# Altium schematic sheet schematic_debugger.SchDoc(schematic_debugger)
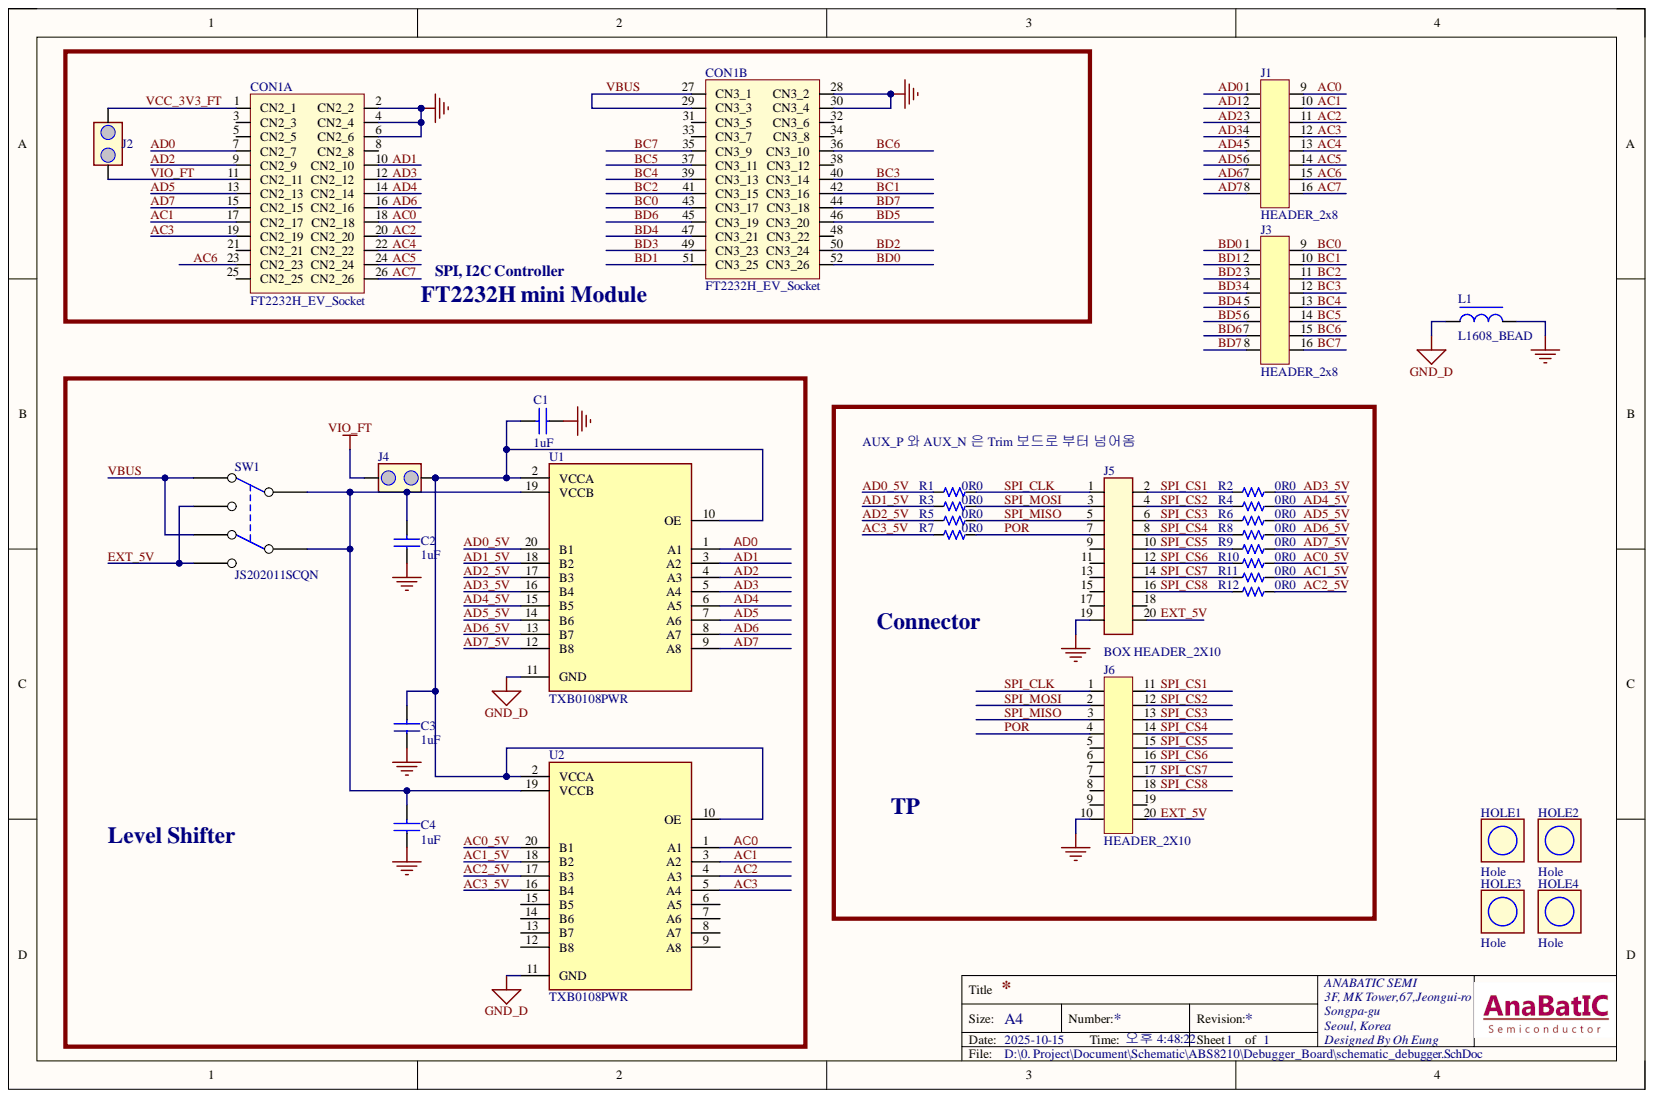
[diagram p0: schematic_debugger.SchDoc]

## Parts
C1  1uF  [C1608]
C2  1uF  [C1608]
C3  1uF  [C1608]
C4  1uF  [C1608]
CON1  FT2232H_EV_Socket  [FT2232H_EV_SOCKET]  (parts A,B)
HOLE1  Hole  [BODY_HOLE]
HOLE2  Hole  [BODY_HOLE]
HOLE3  Hole  [BODY_HOLE]
HOLE4  Hole  [BODY_HOLE]
J1  HEADER_2x8  [HEADER_2X8]
J2  HEADER_1x2  [2PIN]  (value not drawn)
J3  HEADER_2x8  [HEADER_2X8]
J4  HEADER_1x2  [2PIN]  (value not drawn)
J5  BOX HEADER_2X10  [HEADER20_BOX]
J6  HEADER_2X10  [HEADER_2X10]
L1  L1608_BEAD  [L1608]
R1  value 0R0  [R2012]  (hidden comment: RCB)
R2  value 0R0  [R2012]  (hidden comment: RCB)
R3  value 0R0  [R2012]  (hidden comment: RCB)
R4  value 0R0  [R2012]  (hidden comment: RCB)
R5  value 0R0  [R2012]  (hidden comment: RCB)
R6  value 0R0  [R2012]  (hidden comment: RCB)
R7  value 0R0  [R2012]  (hidden comment: RCB)
R8  value 0R0  [R2012]  (hidden comment: RCB)
R9  value 0R0  [R2012]  (hidden comment: RCB)
R10  value 0R0  [R2012]  (hidden comment: RCB)
R11  value 0R0  [R2012]  (hidden comment: RCB)
R12  value 0R0  [R2012]  (hidden comment: RCB)
SW1  JS202011SCQN  [JS202011SCQN]
U1  TXB0108PWR  [SOP65P640X120-20N]
U2  TXB0108PWR  [SOP65P640X120-20N]

## Nets
GND: C1.1 C2.1 C3.1 C4.1 CON1.2(CN2_2) CON1.4(CN2_4) CON1.6(CN2_6) CON1.28(CN3_2) CON1.30(CN3_4) J5.19 J6.10 L1.2
GND_D: L1.1 U1.11(GND) U2.11(GND)
AC0: CON1.18(CN2_18) J1.9 U2.1(A1)
AC0_5V: R10.2 U2.20(B1)
AC1: CON1.17(CN2_17) J1.10 U2.3(A2)
AC1_5V: R11.2 U2.18(B2)
AC2: CON1.20(CN2_20) J1.11 U2.4(A3)
AC2_5V: R12.2 U2.17(B3)
AC3: CON1.19(CN2_19) J1.12 U2.5(A4)
AC3_5V: R7.1 U2.16(B4)
AC4: CON1.22(CN2_22) J1.13
AC5: CON1.24(CN2_24) J1.14
AC6: CON1.23(CN2_23) J1.15
AC7: CON1.26(CN2_26) J1.16
AD0: CON1.7(CN2_7) J1.1 U1.1(A1)
AD0_5V: R1.1 U1.20(B1)
AD1: CON1.10(CN2_10) J1.2 U1.3(A2)
AD1_5V: R3.1 U1.18(B2)
AD2: CON1.9(CN2_9) J1.3 U1.4(A3)
AD2_5V: R5.1 U1.17(B3)
AD3: CON1.12(CN2_12) J1.4 U1.5(A4)
AD3_5V: R2.2 U1.16(B4)
AD4: CON1.14(CN2_14) J1.5 U1.6(A5)
AD4_5V: R4.2 U1.15(B5)
AD5: CON1.13(CN2_13) J1.6 U1.7(A6)
AD5_5V: R6.2 U1.14(B6)
AD6: CON1.16(CN2_16) J1.7 U1.8(A7)
AD6_5V: R8.2 U1.13(B7)
AD7: CON1.15(CN2_15) J1.8 U1.9(A8)
AD7_5V: R9.2 U1.12(B8)
BC0: CON1.43(CN3_17) J3.9
BC1: CON1.42(CN3_16) J3.10
BC2: CON1.41(CN3_15) J3.11
BC3: CON1.40(CN3_14) J3.12
BC4: CON1.39(CN3_13) J3.13
BC5: CON1.37(CN3_11) J3.14
BC6: CON1.36(CN3_10) J3.15
BC7: CON1.35(CN3_9) J3.16
BD0: CON1.52(CN3_26) J3.1
BD1: CON1.51(CN3_25) J3.2
BD2: CON1.50(CN3_24) J3.3
BD3: CON1.49(CN3_23) J3.4
BD4: CON1.47(CN3_21) J3.5
BD5: CON1.46(CN3_20) J3.6
BD6: CON1.45(CN3_19) J3.7
BD7: CON1.44(CN3_18) J3.8
EXT_5V: J5.20 J6.20 SW1.3 SW1.6
POR: J5.7 J6.4 R7.2
SPI_CLK: J5.1 J6.1 R1.2
SPI_CS1: J5.2 J6.11 R2.1
SPI_CS2: J5.4 J6.12 R4.1
SPI_CS3: J5.6 J6.13 R6.1
SPI_CS4: J5.8 J6.14 R8.1
SPI_CS5: J5.10 J6.15 R9.1
SPI_CS6: J5.12 J6.16 R10.1
SPI_CS7: J5.14 J6.17 R11.1
SPI_CS8: J5.16 J6.18 R12.1
SPI_MISO: J5.5 J6.3 R5.2
SPI_MOSI: J5.3 J6.2 R3.2
VBUS: CON1.27(CN3_1) CON1.29(CN3_3) SW1.1 SW1.4
VCC_3V3_FT: CON1.1(CN2_1) J2.2
VIO_FT: CON1.11(CN2_11) J2.1 J4.2
NetC1_2: C1.2 C3.2 J4.1 U1.2(VCCA) U1.10(OE) U2.2(VCCA) U2.10(OE)
NetC2_2: C2.2 C4.2 SW1.2 SW1.5 U1.19(VCCB) U2.19(VCCB)

Not connected: CON1 3(CN2_3) 5(CN2_5) 8(CN2_8) 21(CN2_21) 25(CN2_25) 31(CN3_5) 32(CN3_6) 33(CN3_7) 34(CN3_8) 38(CN3_12) 48(CN3_22); HOLE1 1; HOLE2 1; HOLE3 1; HOLE4 1; J5 9 11 13 15 17 18; J6 5 6 7 8 9 19; U2 6(A5) 7(A6) 8(A7) 9(A8) 12(B8) 13(B7) 14(B6) 15(B5)

## Library data of the parts
C1-C4 = C1608: Component Kind: Standard; Library Name: wireless_altium.IntLib; Signal Integrity: Capacitor; Size: 1608[mm]
CON1 = FT2232H_EV_Socket: 2.54ph box header 2x13, 2EA; MF: FTDI; PN: 2.54Ph SOKCET, 2X13, 2EA; Component Kind: Standard; Library Name: wireless_altium.IntLib
HOLE1-HOLE4 = Hole: Value: NC; Component Kind: Standard; Library Name: wireless_altium.IntLib
J5 = HEADER_BOX_2x10: Component Kind: Standard; Library Name: wireless_altium.IntLib
R1-R12 = RCB: MF: VISHAY; PN: CRCW0805200RFKEA; Component Kind: Standard; Library Name: wireless_altium.IntLib
SW1 = JS202011SCQN: SWITCH SLIDE DPDT 300MA 6V; Component Kind: Standard; Library Name: wireless_altium.IntLib; PN: JS202011SCQN
U1 U2 = TXB0108PWR: 8-Bit Bidirectional Voltage-Level Shifter with Auto Direction Sensing and +/-15-kV ESD Protect; MF: Texas Instruments; Check_prices: https://www.snapeda.com/parts/TXB0108PWR/Texas+Instruments/view-part/?ref=eda; Component Kind: Standard; Digi-Key_Part_Number: 296-21527-2-ND; Library Name: wireless_altium.IntLib; MP: TXB0108PWR; Package: TSSOP-20 Texas Instruments; Purchase-URL: https://pricing.snapeda.com/search/part/TXB0108PWR/?ref=eda; SnapEDA_Link: https://www.snapeda.com/parts/TXB0108PWR/Texas+Instruments/view-part/?ref=snap
J1 J3 = HEADER_2x8: Component Kind: Standard; Library Name: wireless_altium.IntLib
J2 J4 = HEADER_1x2: Component Kind: Standard; Library Name: wireless_altium.IntLib
J6 = HEADER_2X10: Component Kind: Standard; Library Name: wireless_altium.IntLib
L1 = L1608_BEAD: Component Kind: Standard; Library Name: wireless_altium.IntLib; Size: 1608[mm]
